# Altium schematic sheet KURTARMA.SchDoc(KURTARMA)
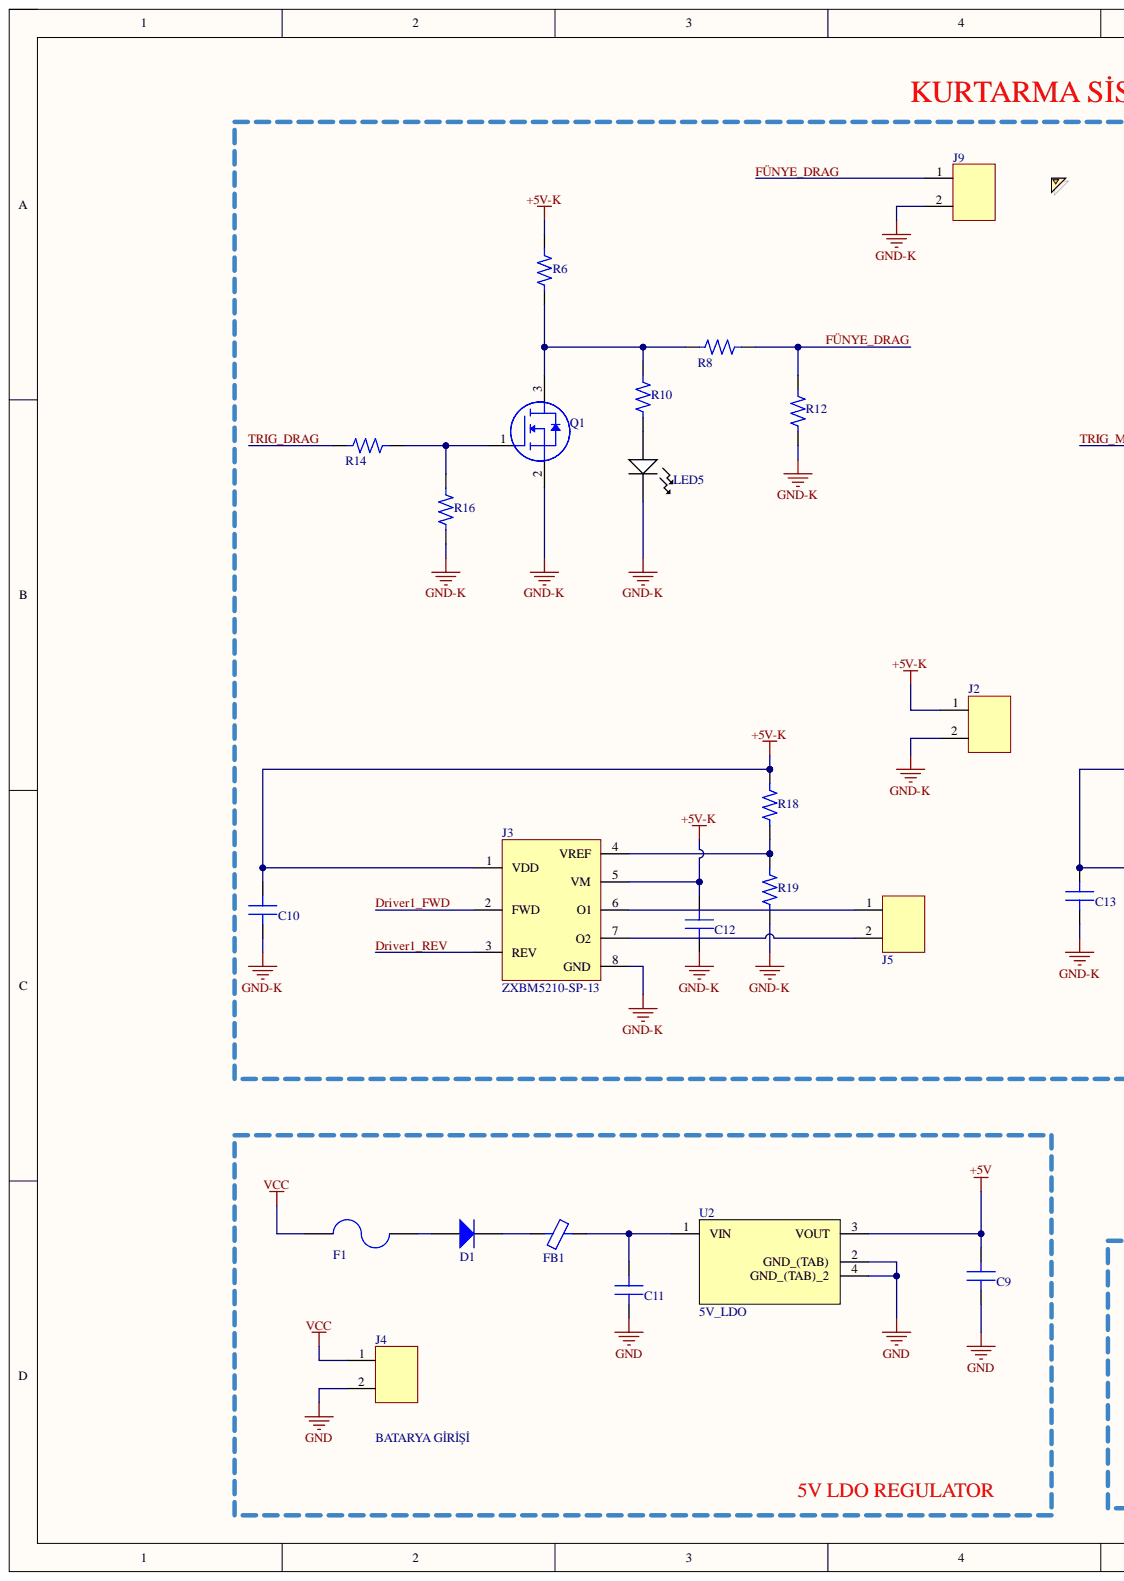
[diagram p0: KURTARMA.SchDoc, left]
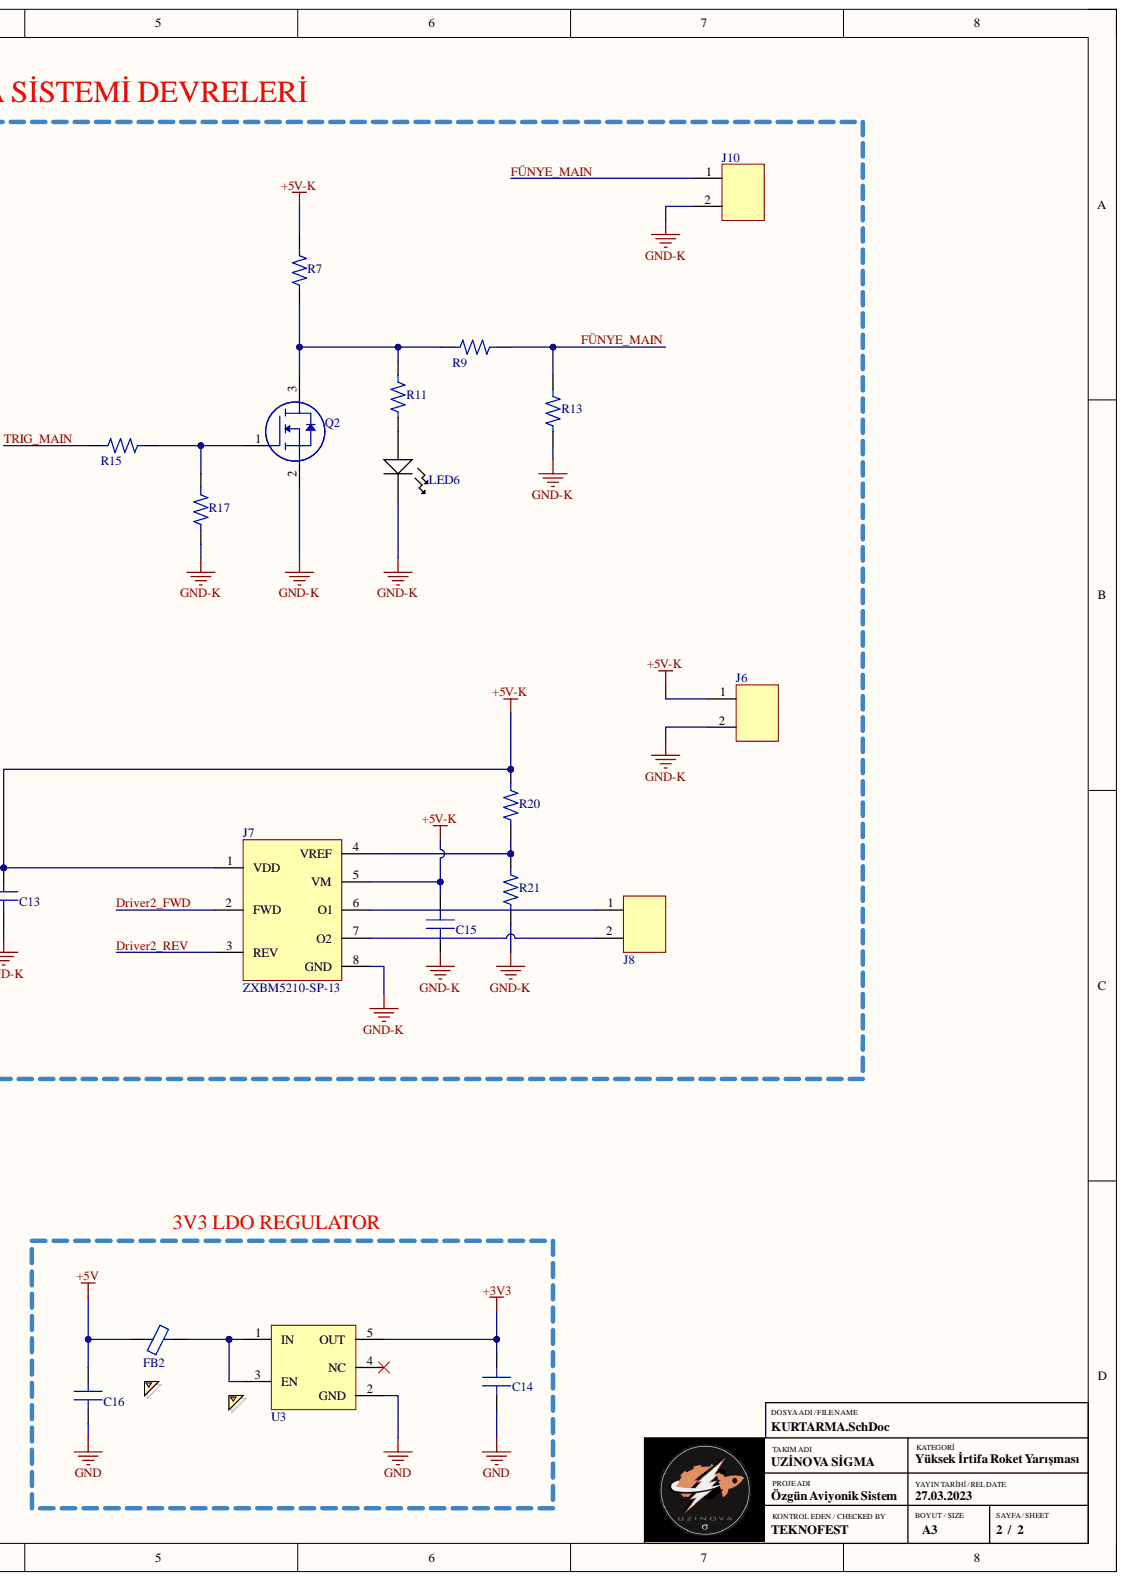
[diagram p1: KURTARMA.SchDoc, right]

## Parts
C9  CAP CER 10uF 0805  [CAP_0805]  (value not drawn)
C10  CAP CER 1uF 0805  [CAP_0805]  (value not drawn)
C11  CAP CER 10uF 0805  [CAP_0805]  (value not drawn)
C12  CAP CER 10uF 0805  [CAP_0805]  (value not drawn)
C13  CAP CER 1uF 0805  [CAP_0805]  (value not drawn)
C14  CAP CER 1uF 0805  [CAP_0805]  (value not drawn)
C15  CAP CER 10uF 0805  [CAP_0805]  (value not drawn)
C16  CAP CER 1uF 0805  [CAP_0805]  (value not drawn)
D1  DIODE GEN PURP 75V 150MA SOD323F  [SOD323F]  (value not drawn)
F1  FUSE 3413.0216.22  [FUSE_1206]  (value not drawn)
FB1  MPZ2012S601AT000  [FERRITE BEAD_0805]  (value not drawn)
FB2  MPZ2012S601AT000  [FERRITE BEAD_0805]  (value not drawn)
J2  Mike Connector  [MIKE CONNECTOR]  (value not drawn)
J3  ZXBM5210-SP-13  [SO-8EP]
J4  Mike Connector  [MIKE CONNECTOR]  (value not drawn)
J5  HEADER x2  [HEADER x2]  (value not drawn)
J6  Mike Connector  [MIKE CONNECTOR]  (value not drawn)
J7  ZXBM5210-SP-13  [SO-8EP]
J8  HEADER x2  [HEADER x2]  (value not drawn)
J9  Mike Connector  [MIKE CONNECTOR]  (value not drawn)
J10  Mike Connector  [MIKE CONNECTOR]  (value not drawn)
LED5  CMD17-21VRD/TR8  [LED RED_0805]  (value not drawn)
LED6  CMD17-21VRD/TR8  [LED RED_0805]  (value not drawn)
Q1  NTR5103NT1G  [SOT-23-3]  (value not drawn)
Q2  NTR5103NT1G  [SOT-23-3]  (value not drawn)
R6  RES 0 OHM 0805  [RES_0805]  (value not drawn)
R7  RES 0 OHM 0805  [RES_0805]  (value not drawn)
R8  RES 20K OHM 0805  [RES_0805]  (value not drawn)
R9  RES 20K OHM 0805  [RES_0805]  (value not drawn)
R10  RES 470 OHM 0805  [RES_0805]  (value not drawn)
R11  RES 470 OHM 0805  [RES_0805]  (value not drawn)
R12  RES 10K OHM 0805  [RES_0805]  (value not drawn)
R13  RES 10K OHM 0805  [RES_0805]  (value not drawn)
R14  RES 1K OHM 0805  [RES_0805]  (value not drawn)
R15  RES 1K OHM 0805  [RES_0805]  (value not drawn)
R16  RES 10K OHM 0805  [RES_0805]  (value not drawn)
R17  RES 10K OHM 0805  [RES_0805]  (value not drawn)
R18  RES 1K OHM 0805  [RES_0805]  (value not drawn)
R19  RES 1K OHM 0805  [RES_0805]  (value not drawn)
R20  RES 1K OHM 0805  [RES_0805]  (value not drawn)
R21  RES 1K OHM 0805  [RES_0805]  (value not drawn)
U2  5V_LDO  [5V_LDO]
U3  NCP161ASN330T1G  [SOT-23-5]  (value not drawn)

## Nets
+3V3: C14.2 U3.5(OUT)
+5V: C9.2 C16.2 FB2.1 U2.3(VOUT)
+5V-K: C10.2 C12.2 C13.2 C15.2 J2.1 J3.1(VDD) J3.5(VM) J6.1 J7.1(VDD) J7.5(VM) R6.2 R7.2 R18.2 R20.2
GND: C9.1 C11.1 C14.1 C16.1 J4.2 U2.2(GND_(TAB)) U2.4(GND_(TAB)_2) U3.2(GND)
GND-K: C10.1 C12.1 C13.1 C15.1 J2.2 J3.8(GND) J6.2 J7.8(GND) J9.2 J10.2 LED5.2 LED6.2 Q1.2 Q2.2 R12.1 R13.1 R16.1 R17.1 R19.1 R21.1
VCC: F1.1 J4.1
Driver1_FWD: J3.2(FWD)
Driver1_REV: J3.3(REV)
Driver2_FWD: J7.2(FWD)
Driver2_REV: J7.3(REV)
FÜNYE_DRAG: J9.1 R8.2 R12.2
FÜNYE_MAIN: J10.1 R9.2 R13.2
TRIG_DRAG: R14.1
TRIG_MAIN: R15.1
NetC11_2: C11.2 FB1.2 U2.1(VIN)
NetD1_1: D1.1 FB1.1
NetD1_2: D1.2 F1.2
NetFB2_2: FB2.2 U3.1(IN) U3.3(EN)
NetJ3_4: J3.4(VREF) R18.1 R19.2
NetJ3_6: J3.6(O1) J5.1
NetJ3_7: J3.7(O2) J5.2
NetJ7_4: J7.4(VREF) R20.1 R21.2
NetJ7_6: J7.6(O1) J8.1
NetJ7_7: J7.7(O2) J8.2
NetLED5_1: LED5.1 R10.1
NetLED6_1: LED6.1 R11.1
NetQ1_1: Q1.1 R14.2 R16.2
NetQ1_3: Q1.3 R6.1 R8.1 R10.2
NetQ2_1: Q2.1 R15.2 R17.2
NetQ2_3: Q2.3 R7.1 R9.1 R11.2

Not connected: U3 4(NC)

## Library data of the parts
D1 = DIODE: VR=75V IF=150mA Trr=4ns P=200mW; Manufacturer: ON Semiconductor / Fairchild; Manufacturer Part Number: 1N4148WS; Element Configuration: Single; Forward Voltage: 1V; Max Forward Surge Current (Ifsm): 2A; Max Reverse Current: 5uA; Power Dissipation: 200mW; Recovery Time: 4ns; Average Rectified Current: 150mA; Category: Rectifier Diodes; Component Kind: Standard; ComponentLink1Description: https://datasheet.ciiva.com/30479/0900766b810e1cd3-30479206.pdf?src-supplier=Rs; ComponentLink1URL: https://datasheet.ciiva.com/30479/0900766b810e1cd3-30479206.pdf?src-supplier=Rs; ComponentLink2Description: https://datasheet.ciiva.com/15208/getdatasheetpartid-127819-15208358.pdf?src-supplier=Verical; ComponentLink2URL: https://datasheet.ciiva.com/15208/getdatasheetpartid-127819-15208358.pdf?src-supplier=Verical; ComponentLink3Description: https://datasheet.ciiva.com/8300/1n914bws-8300360.pdf?src-supplier=Avnet; ComponentLink3URL: https://datasheet.ciiva.com/8300/1n914bws-8300360.pdf?src-supplier=Avnet; ComponentLink4Description: https://datasheet.ciiva.com/910/1n4148ws-910381.pdf?src-supplier=Digi-Key; ComponentLink4URL: https://datasheet.ciiva.com/910/1n4148ws-910381.pdf?src-supplier=Digi-Key; ComponentLink5Description: https://datasheet.ciiva.com/910/1n4148ws-910382.pdf?src-supplier=Digi-Key; ComponentLink5URL: https://datasheet.ciiva.com/910/1n4148ws-910382.pdf?src-supplier=Digi-Key; ComponentLink6Description: https://datasheet.ciiva.com/910/1n4148ws-910380.pdf?src-supplier=Digi-Key; ComponentLink6URL: https://datasheet.ciiva.com/910/1n4148ws-910380.pdf?src-supplier=Digi-Key; Contact Plating: Tin,Matte; Forward Current: 150mA; Height: 1mm; Lead Free: Lead Free; Length: 1.8mm; Max Operating Temperature: 150°C; Max Repetitive Reverse Voltage (Vrrm): 75V; Max Reverse Voltage (DC): 75V; Min Operating Temperature: -55°C; Mount: Surface Mount; Number of Pins: 2; Package Quantity: 1; Packaging: Tape and Reel; Pricing 1: 1=0,21000, 10=0,19200, 100=0,10460, 500=0,06436, 1000=0,04388 (USD); Radiation Hardening: No; Reach SVHC Compliant: Yes; Reverse Recovery Time: 4ns; Reverse Voltage: 75V; RoHS: Yes; RoHS Compliant: Yes; Series: -; Stock 1: 973654; Supplier 1: Digi-Key; Supplier Part Number 1: 1N4148WSFSDKR-ND; Weight: 0.0276g; Width: 1.35mm; Library Name: ROKET_LIBRARY.IntLib
F1 = FUSE: Fuse Chip Fast Acting 1A 32V SMD Solder Pad 1206 Glass T/R VDE/cULus; Manufacturer: Schurter; Approvals: VDE,cURus; Body Material: Glass,Epoxy; DC Cold Resistance: 94.2mR; Fuse Type: Board Mount (Cartridge Style Excluded); Max Voltage Rating (DC): 63V; Case Code (Metric): 3216; Case Code (Imperial): 1206; Case/Package: 1206; Category: Fuses; Component Kind: Standard; ComponentLink1Description: https://datasheet.ciiva.com/301/typ-usi-1206-301440.pdf?src-supplier=Digi-Key; ComponentLink1URL: https://datasheet.ciiva.com/301/typ-usi-1206-301440.pdf?src-supplier=Digi-Key; ComponentLink2Description: https://datasheet.ciiva.com/14541/70160006-14541114.pdf?src-supplier=Allied+Electronics; ComponentLink2URL: https://datasheet.ciiva.com/14541/70160006-14541114.pdf?src-supplier=Allied+Electronics; ComponentLink3Description: https://datasheet.ciiva.com/301/typ-usi-1206-301439.pdf?src-supplier=Digi-Key; ComponentLink3URL: https://datasheet.ciiva.com/301/typ-usi-1206-301439.pdf?src-supplier=Digi-Key; ComponentLink4Description: https://datasheet.ciiva.com/301/typ-usi-1206-301441.pdf?src-supplier=Digi-Key; ComponentLink4URL: https://datasheet.ciiva.com/301/typ-usi-1206-301441.pdf?src-supplier=Digi-Key; ComponentLink5Description: https://datasheet.ciiva.com/8264/typ-usi-1206-8264583.pdf?src-supplier=Avnet; ComponentLink5URL: https://datasheet.ciiva.com/8264/typ-usi-1206-8264583.pdf?src-supplier=Avnet; ComponentLink6Description: https://datasheet.ciiva.com/14102/qousi1206-data-e-14102903.pdf?src-supplier=Distrelec; ComponentLink6URL: https://datasheet.ciiva.com/14102/qousi1206-data-e-14102903.pdf?src-supplier=Distrelec; ComponentLink7Description: https://datasheet.ciiva.com/16316/typ-usi-1206-16316588.pdf?src-supplier=Future+Electronics; ComponentLink7URL: https://datasheet.ciiva.com/16316/typ-usi-1206-16316588.pdf?src-supplier=Future+Electronics; Contact Plating: Gold; Current Rating: 1A; Depth: 1.6mm; Height: 0.6mm; Housing Material: Glass; Lead Free: Lead Free; Length: 3.2mm; Manufacturer Part Number: 3413.0216.22; Max Operating Temperature: 90°C; Max Voltage Rating (AC): 32V; Min Operating Temperature: -55°C; Mount: Surface Mount,PCB; Number of Pins: 2; Package Quantity: 1000; Packaging: Tape and Reel; Pricing 1: 1=0,67000, 50=0,52400, 100=0,39700, 500=0,32624 (USD); Radiation Hardening: No; RoHS: Yes; RoHS Compliant: Yes; Series: USI 1206; Stock 1: 6832; Supplier 1: Digi-Key; Supplier Part Number 1: 486-1144-1-ND; Terminal Material: Tin; Termination: SMD/SMT; Voltage Rating: 32V; Voltage Rating (AC): 32V; Voltage Rating (DC): 63V; Weight: 0.000212oz; Width: 1.6mm; Working Voltage: 32V; Library Name: ROKET_LIBRARY.IntLib
FB1 FB2 = FERRITE BEAD: 0805 600Ω ±25% DCR=0.1Ω 2A; Manufacturer: TDK; DC Resistance (DCR): 100mR; Number of Lines: 1; Impedance: 600R; Case Code (Metric): 2012; Case Code (Imperial): 0805; Case/Package: 0805; Category: Ferrite Beads and Chips; Component Kind: Standard; ComponentLink1Description: https://datasheet.ciiva.com/46634/beads-commercial-power-mpz-en-46634335.pdf?src-supplier=Digi-Key; ComponentLink1URL: https://datasheet.ciiva.com/46634/beads-commercial-power-mpz-en-46634335.pdf?src-supplier=Digi-Key; ComponentLink2Description: https://datasheet.ciiva.com/46634/beads-commercial-power-mpz-en-46634377.pdf?src-supplier=Digi-Key; ComponentLink2URL: https://datasheet.ciiva.com/46634/beads-commercial-power-mpz-en-46634377.pdf?src-supplier=Digi-Key; ComponentLink3Description: https://datasheet.ciiva.com/46634/beads-commercial-power-mpz-en-46634606.pdf?src-supplier=Digi-Key; ComponentLink3URL: https://datasheet.ciiva.com/46634/beads-commercial-power-mpz-en-46634606.pdf?src-supplier=Digi-Key; Current Rating: 2A; Height: 0.041inch; Lead Free: Lead Free; Length: 0.079inch; Manufacturer Part Number: MPZ2012S601AT000; Max Current Rating: 2A; Max DC Current: 2A; Max Operating Temperature: 125°C; Min Operating Temperature: -55°C; Mount: Surface Mount; Package Quantity: 1; Packaging: Tape and Reel; Pricing 1: 1=0,11000, 10=0,09200, 25=0,08320, 50=0,06100, 100=0,05270, 250=0,04160, 500=0,03512, 1000=0,02957 (USD); Reach SVHC Compliant: Yes; RoHS: Yes; RoHS Compliant: Yes; Series: MPZ; Stock 1: 1942; Supplier 1: Digi-Key; Supplier Part Number 1: 445-MPZ2012S601AT000CT-ND; Width: 0.049inch; Library Name: ROKET_LIBRARY.IntLib
J3 J7 = Driver: ZXBM5210 Series 18 V 1.5 A Reversible DC Motor Drive with Speed Control-SOIC-8EP; Manufacturer: Diodes; Logic Function: AND GATE; Operating Supply Voltage: 18V; Interface: PWM; Category: Motor Drivers; Component Kind: Standard; ComponentLink1Description: https://datasheet.ciiva.com/29599/zxbm5210-29599456.pdf?src-supplier=Digi-Key; ComponentLink1URL: https://datasheet.ciiva.com/29599/zxbm5210-29599456.pdf?src-supplier=Digi-Key; ComponentLink2Description: https://datasheet.ciiva.com/29599/zxbm5210-29599455.pdf?src-supplier=Digi-Key; ComponentLink2URL: https://datasheet.ciiva.com/29599/zxbm5210-29599455.pdf?src-supplier=Digi-Key; ComponentLink3Description: https://datasheet.ciiva.com/30043/zxbm5210-30043882.pdf?src-supplier=Avnet; ComponentLink3URL: https://datasheet.ciiva.com/30043/zxbm5210-30043882.pdf?src-supplier=Avnet; Lead Free: Lead Free; Manufacturer Part Number: ZXBM5210-SP-13; Max Operating Temperature: 125°C; Min Operating Temperature: -40°C; Mount: Surface Mount; Package Quantity: 2500; Packaging: Cut Tape; Pricing 1: 1=1,21000, 10=1,08000, 25=1,02560, 100=0,84230, 250=0,78740, 500=0,69584, 1000=0,54934 (USD); RoHS: Yes; Stock 1: 172511; Supplier 1: Digi-Key; Supplier Part Number 1: ZXBM5210-SP-13DICT-ND; Library Name: Roket_Library.SchLib
J5 J8 = HEADER x2: Conn Screw Type Terminal Block 2 POS 3.5mm Solder ST Thru-Hole 10A; Manufacturer: On-Shore Technology; Manufacturer Part Number: OSTTE020104; Number of Levels: 1; Number of Positions Per Level: 2; Pitch: 0.138inch; Plating: Steel; Voltage: 125V; Wire Gauge (Max): 26AWG; Wire Gauge (Min): 16AWG; Category: Terminal Blocks; Color: Black; Component Kind: Standard; ComponentLink1Description: http://www.on-shore.com/wp-content/uploads/2015/09/osttexx0164.pdf?src-supplier=Digi-Key; ComponentLink1URL: http://www.on-shore.com/wp-content/uploads/2015/09/osttexx0164.pdf?src-supplier=Digi-Key; ComponentLink2Description: http://www.on-shore.com/wp-content/uploads/2015/09/osttexx0164.pdf?src-supplier=Verical; ComponentLink2URL: http://www.on-shore.com/wp-content/uploads/2015/09/osttexx0164.pdf?src-supplier=Verical; ComponentLink3Description: http://www.on-shore.com/wp-content/uploads/2015/09/osttexx0164.pdf?src-supplier=Arrow+Electronics; ComponentLink3URL: http://www.on-shore.com/wp-content/uploads/2015/09/osttexx0164.pdf?src-supplier=Arrow+Electronics; Contact Plating: Tin; Current: 10A; Housing Material: Thermoplastic; Max Operating Temperature: 105°C; Min Operating Temperature: -30°C; Mount: Through Hole; Number of Pins: 2; Packaging: Bulk; Pricing 1: 1=0,74000, 10=0,40200, 25=0,30040, 50=0,26780, 100=0,26260, 250=0,23108, 500=0,21008, 1000=0,18907, 5000=0,14180 (USD); RoHS: Yes; Stock 1: 73362; Supplier 1: Digi-Key; Supplier Part Number 1: ED2740-ND; Termination: Screw; Library Name: ROKET_LIBRARY.IntLib
LED5 LED6 = LED RED: LED Uni-Color Red 640nm 2-Pin SMT T/R; Manufacturer: VCC CHML; Forward Current: 20mA; Forward Voltage: 2V; Lens Style: Diffused,Tinted,Rectangular,Colored; Luminous Intensity: 6mcd; Number of LEDs: 1; Power Rating: 100mW; Reverse Voltage: 5V; Viewing Angle: 140°; Case Code (Metric): 2012; Case Code (Imperial): 0805; Case/Package: 0805; Category: LEDs; Color: Red; Component Kind: Standard; ComponentLink1Description: http://www.mouser.com/ds/2/423/CMD1721ver1-1064576.pdf?src-supplier=Mouser; ComponentLink1URL: http://www.mouser.com/ds/2/423/CMD1721ver1-1064576.pdf?src-supplier=Mouser; ComponentLink2Description: https://www.alliedelec.com/m/d/ea8f758becbd32522d2612194452bcae.pdf?src-supplier=Allied+Electronics; ComponentLink2URL: https://www.alliedelec.com/m/d/ea8f758becbd32522d2612194452bcae.pdf?src-supplier=Allied+Electronics; ComponentLink3Description: http://www.onlinecomponents.com/productFiles/mf-CM/2cmd17-21seriessmtleds0805packagesize.pdf?src-supplier=Onlinecomponents.com; ComponentLink3URL: http://www.onlinecomponents.com/productFiles/mf-CM/2cmd17-21seriessmtleds0805packagesize.pdf?src-supplier=Onlinecomponents.com; ComponentLink4Description: https://vcclite.com/wp-content/uploads/wpallimport/files/files/CMD1721Seriesver3.pdf?src-supplier=Digi-Key; ComponentLink4URL: https://vcclite.com/wp-content/uploads/wpallimport/files/files/CMD1721Seriesver3.pdf?src-supplier=Digi-Key; Height: 1.1mm; Lead Free: Lead Free; Length: 2mm; Lens Color: Red; Manufacturer Part Number: CMD17-21VRD/TR8; Max Operating Temperature: 85°C; Min Operating Temperature: -40°C; Mount: Surface Mount; Number of Pins: 2; Package Quantity: 1; Packaging: Tape and Reel; Pricing 1: 1=0,73000, 10=0,47800, 100=0,31310, 1000=0,24720 (USD); Reverse Voltage (DC): 5V; RoHS: Yes; RoHS Compliant: Yes; Stock 1: 357228; Supplier 1: Digi-Key; Supplier Part Number 1: L62501CT-ND; Test Current: 20mA; Wavelength: 640nm; Width: 1.25mm; Illumination Color: Red; Library Name: ROKET_LIBRARY.IntLib
Q1 Q2 = MOSFET N-Channel: MOSFET N-CH 60V 0.26A SOT23; Manufacturer: ON Semiconductor; Continuous Drain Current (ID): 310mA; Drain to Source Resistance: 1R; Fall Time: 3.6ns; FET Type: 1 N-Channel; Gate to Source Voltage (Vgs): 30V; Max Power Dissipation: 300mW; Number of Channels: 1; Rds On Max: 2.5R; Rise Time: 1.2ns; Turn-Off Delay Time: 4.8ns; Turn-On Delay Time: 1.7ns; Input Capacitance: 40pF; Case/Package: SOT-23-3; Category: MOSFETs; Component Kind: Standard; ComponentLink1Description: http://www.farnell.com/datasheets/2237046.pdf?src-supplier=Farnell; ComponentLink1URL: http://www.farnell.com/datasheets/2237046.pdf?src-supplier=Farnell; ComponentLink2Description: http://www.mouser.com/ds/2/308/NTR5103N-D-600006.pdf?src-supplier=Mouser; ComponentLink2URL: http://www.mouser.com/ds/2/308/NTR5103N-D-600006.pdf?src-supplier=Mouser; ComponentLink3Description: http://www.farnell.com/datasheets/2237046.pdf?src-supplier=Newark; ComponentLink3URL: http://www.farnell.com/datasheets/2237046.pdf?src-supplier=Newark; ComponentLink4Description: http://www.farnell.com/datasheets/2237046.pdf?src-supplier=Element14; ComponentLink4URL: http://www.farnell.com/datasheets/2237046.pdf?src-supplier=Element14; ComponentLink5Description: http://www.onsemi.com/pub/Collateral/NTR5103N-D.PDF?src-supplier=Avnet; ComponentLink5URL: http://www.onsemi.com/pub/Collateral/NTR5103N-D.PDF?src-supplier=Avnet; ComponentLink6Description: http://www.onsemi.com/pub/Collateral/NTR5103N-D.PDF?src-supplier=Digi-Key; ComponentLink6URL: http://www.onsemi.com/pub/Collateral/NTR5103N-D.PDF?src-supplier=Digi-Key; Contact Plating: Tin; Drain to Source Breakdown Voltage: 60V; Drain to Source Voltage (Vdss): 60V; Lead Free: Lead Free; Manufacturer Part Number: NTR5103NT1G; Max Operating Temperature: 150°C; Min Operating Temperature: -55°C; Mount: Surface Mount; Number of Pins: 3; Package Quantity: 3000; Packaging: Tape and Reel; Pricing 1: 1=0,25000, 10=0,20100, 100=0,10660, 500=0,07012, 1000=0,04768 (USD); RoHS: Yes; RoHS Compliant: Yes; Stock 1: 55356; Supplier 1: Digi-Key; Supplier Part Number 1: NTR5103NT1GOSCT-ND; Library Name: ROKET_LIBRARY.IntLib
U3 = NCP161ASN330T1G: LDO Regulator, 450 mA, Ultra-High PSRR, Ultra-Low Noise 3.3V, SOT23-5L, Active Discharge; Manufacturer: ON Semiconductor; Current - Output: 450mA; Voltage - Input (Max): 5.5V; Category: Voltage Regulators - Linear; Component Kind: Standard; ComponentLink1Description: http://www.farnell.com/datasheets/2815754.pdf?src-supplier=Farnell; ComponentLink1URL: http://www.farnell.com/datasheets/2815754.pdf?src-supplier=Farnell; Manufacturer Part Number: NCP161ASN330T1G; Pricing 1: 1=0,67000, 10=0,58900, 25=0,55320, 100=0,45160, 250=0,41948, 500=0,35700, 1000=0,28561 (USD); RoHS: Yes; RoHS Compliant: Yes; Stock 1: 4959; Supplier 1: Digi-Key; Supplier Device Package: SOT-23-5; Supplier Part Number 1: NCP161ASN330T1GOSCT-ND; Voltage - Output (Min/Fixed): 3.3V; Library Name: ROKET_LIBRARY.IntLib
C9 C11 C12 C15 = CAP CER 10uF 0805: Component Kind: Standard; Library Name: ROKET_LIBRARY.IntLib
C10 C13 C14 C16 = CAP CER 1uF 0805: Component Kind: Standard; Library Name: ROKET_LIBRARY.IntLib
J2 J4 J6 J9 J10 = Mike Connector: Component Kind: Standard; Library Name: ROKET_LIBRARY.IntLib
R6 R7 = RES 0 OHM 0805: Component Kind: Standard; Library Name: ROKET_LIBRARY.IntLib
R8 R9 = RES 20K OHM 0805: Component Kind: Standard; Library Name: ROKET_LIBRARY.IntLib
R10 R11 = RES 470 OHM 0805: Component Kind: Standard; Library Name: ROKET_LIBRARY.IntLib
R12 R13 R16 R17 = RES 10K OHM 0805: Component Kind: Standard; Library Name: ROKET_LIBRARY.IntLib
R14 R15 R18-R21 = RES 1K OHM 0805: Component Kind: Standard; Library Name: ROKET_LIBRARY.IntLib
U2 = 5V_LDO: Component Kind: Standard; Library Name: ROKET_LIBRARY.IntLib
